# Altium schematic sheet ble_seismometer.SchDoc
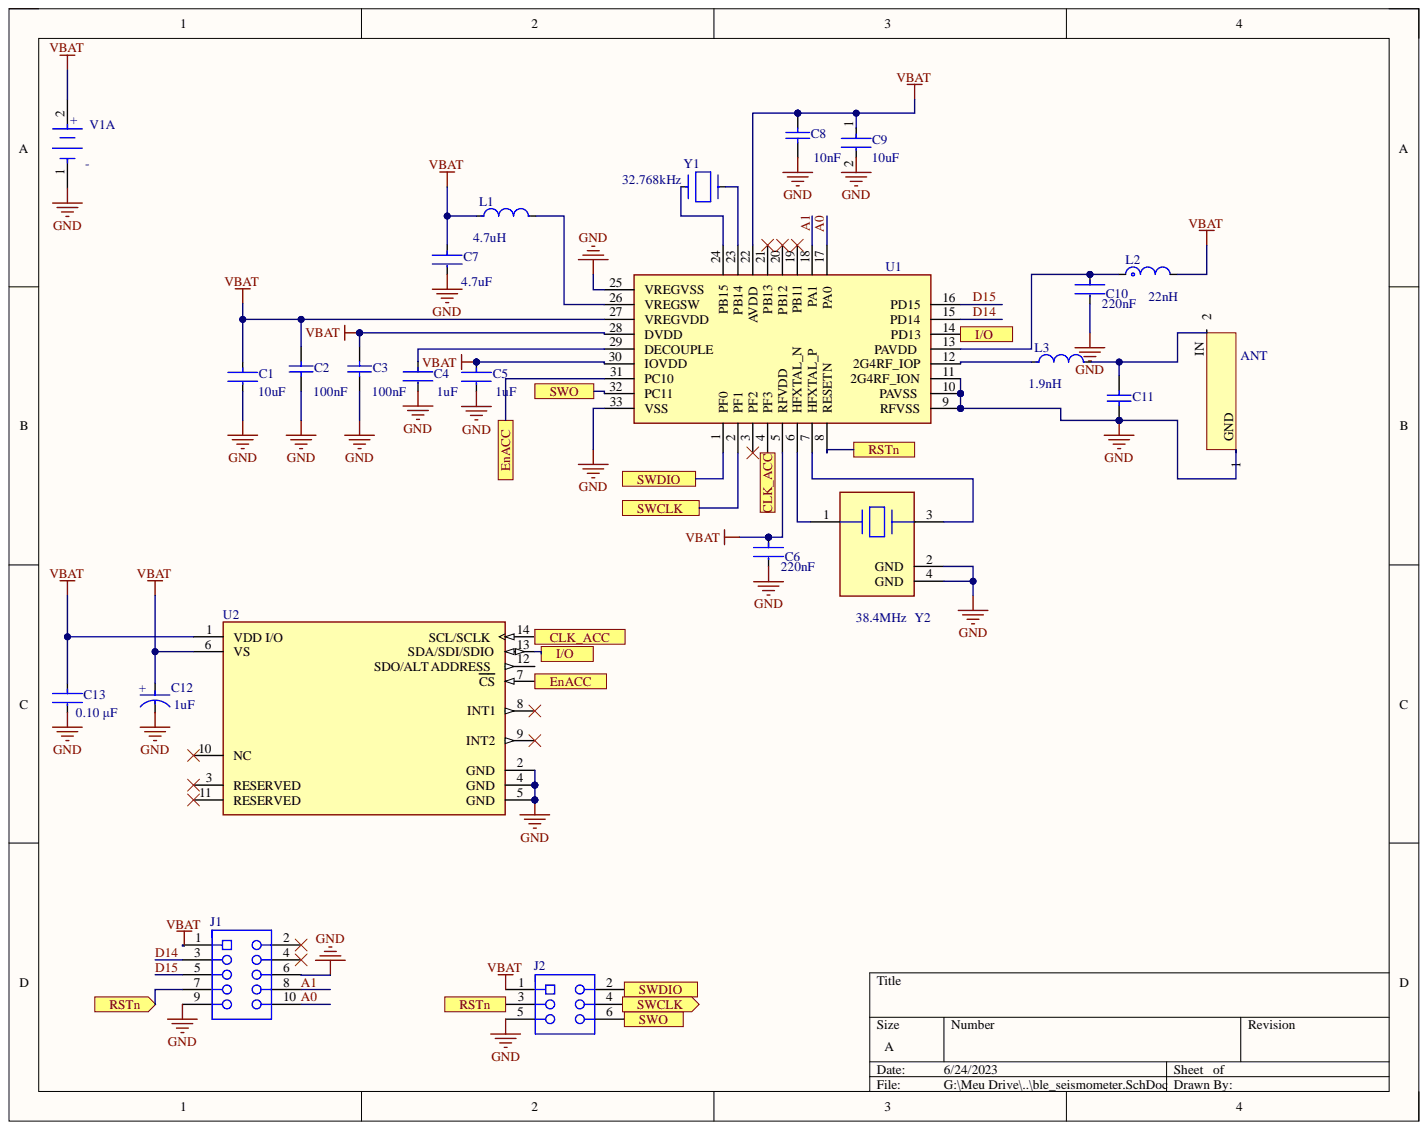
[diagram p0: ble_seismometer.SchDoc]

## Parts
ANT  Inverted F Antenna  [InvertedF-FOOTPRINT]  (value not drawn)
C1  Capacitance: 10uF  [FP-C1608-080-0_1-MFG]  (hidden comment: C1608X5R1A106K080AC)
C2  Capacitance: 100nF  [CAPC1608X90X35ML10T15]  (hidden comment: GRM188R71H104KA93D)
C3  Capacitance: 100nF  [CAPC1608X90X35ML10T15]  (hidden comment: GRM188R71H104KA93D)
C4  Capacitance: 1uF  [FP-C0402C105K8PACTU-MFG]  (hidden comment: C0402C105K8PACTU)
C5  Capacitance: 1uF  [FP-C0402C105K8PACTU-MFG]  (hidden comment: C0402C105K8PACTU)
C6  Capacitance: 220nF  [FP-GRM188-0_1-e0_2_0_5-IPC_C]  (hidden comment: GRM188R71E224KA88D)
C7  Capacitance: 4.7uF  [FP-GRM188-0_1-MFG]  (hidden comment: GRM188R60J475KE19D)
C8  Capacitance: 10nF  [CAPC1608X90X35ML10T15]  (hidden comment: GRM188R71H103KA01D)
C9  Capacitance: 10uF  [FP-GRM188-0_1-e0_2_0_55-IPC_A]  (hidden comment: GRM188R60J106ME47D)
C10  Capacitance: 220nF  [FP-GRM188-0_1-e0_2_0_5-IPC_C]  (hidden comment: GRM188R71E224KA88D)
C11  VJ0603D1R5BXPAJ  [CAPC1608X92X38ML15T08]  (value not drawn)
C12  value 1uF  [FP-F98M-MFG]  (hidden comment: F981E105MMA)
C13  value 0.10 μF  Capacitance: 0.10 μF  [FP-C0603C-CF-MFG]  (hidden comment: C0603C104K5RACTU)
J1  61301021821  [61301021821]  (value not drawn)
J2  62000621821  [62000621821]  (value not drawn)
L1  LQM18FN4R7M00D  [FP-LQM18FN_00-IPC_A]  (value not drawn)
L2  Inductance: 22nH  [FP-LQG18HH_00-IPC_C]  (hidden comment: LQG18HN22NJ00D)
L3  Inductance: 1.9nH  [FP-LQW03AW_00-MFG]  (hidden comment: LQW03AW1N9C00D)
U1  EFR32BG13P632F512GM32-D  [EFR32BG13P632F512GM32-D]  (value not drawn)
U2  ADXL345BCCZ-RL7  [FP-CC-14-1-MFG]  (value not drawn)
V1  1013  [FP-1013-MFG]  (value not drawn)  (part A)
Y1  Frequency: 32.768kHz  [FP-ABS05-MFG]  (hidden comment: ABS05-32.768KHZ-T)
Y2  Frequency: 38.4MHz  [FP-ABM10-165-MFG]  (hidden comment: ABM10-165-38.400MHZ-T3)

## Ports
CLK_ACC, EnACC, I/O, RSTn, SWCLK, SWDIO, SWO

## Nets
GND: ANT.GND C1.1 C2.1 C3.1 C4.2 C5.2 C6.2 C7.1 C8.1 C9.2 C10.2 C11.1 J1.6 J1.9 J2.5 U1.9(RFVSS) U1.10(PAVSS) U1.11(2G4RF_ION) U1.25(VREGVSS) U1.33(VSS) U2.2(GND) U2.4(GND) U2.5(GND) V1.1 Y2.2(GND) Y2.4(GND)
VBAT: C1.2 C2.2 C3.2 C5.1 C6.1 C7.2 C8.2 C9.1 C12.2 C13.1 J1.1 J2.1 L1.1 L2.2 U1.5(RFVDD) U1.22(AVDD) U1.27(VREGVDD) U1.28(DVDD) U1.30(IOVDD) U2.1(VDD_I/O) U2.6(VS) V1.2
A0: J1.10 U1.17(PA0)
A1: J1.8
CLK_ACC: U1.4(PF3) U2.14(SCL/SCLK) ; port CLK_ACC
D14: J1.3 U1.15(PD14)
EnACC: U1.31(PC10) U2.7(CS) ; port EnACC
I/O: U1.14(PD13) U2.13(SDA/SDI/SDIO) ; port I/O
RSTn: J2.3 U1.8(RESETN) ; port RSTn
SWCLK: U1.2(PF1) ; port SWCLK
SWDIO: J2.2 U1.1(PF0) ; port SWDIO
SWO: J2.6 U1.32(PC11) ; port SWO
NetANT_IN: ANT.IN C11.2 L3.2
NetC4_1: C4.1 U1.29(DECOUPLE)
NetC10_1: C10.1 L2.1 U1.13(PAVDD)
NetL1_2: L1.2 U1.26(VREGSW)
NetL3_1: L3.1 U1.12(2G4RF_IOP)
NetU1_6: U1.6(HFXTAL_N) Y2.1
NetU1_7: U1.7(HFXTAL_P) Y2.3
NetU1_23: U1.23(PB14) Y1.2
NetU1_24: U1.24(PB15) Y1.1
D15:

Not connected: C12 1; C13 2; J1 2 4 5 7; J2 4; U1 3(PF2) 16(PD15) 18(PA1) 19(PB11) 20(PB12) 21(PB13); U2 3(RESERVED) 8(INT1) 9(INT2) 10(NC) 11(RESERVED) 12(SDO/ALT_ADDRESS)

## Library data of the parts
ANT = InvertedF: Component Kind: Net Tie; Description: Inverted F Antenna; Library Name: InvertedF.SchLib
C1 = CMP-2000-04979-3: Multilayer Ceramic Capacitors 10μF ±10% 10V X5R SMD 0603; Case Code (Imperial): 0603; Case Code (Metric): 1608; Case/Package: 0603; Component Kind: Standard; Construction: Flat; Depth: 0.8mm; Dielectric: X5R; Dielectric Material: Ceramic; Height: 0.8mm; Lead Free: Lead Free; Length: 1.6mm; Library Name: Altium Content Vault; Max Operating Temperature: 85°C; Min Operating Temperature: -55°C; Mount: Surface Mount; Number of Pins: 2; Package Quantity: 1; Packaging: Tape and Reel; Radiation Hardening: No; REACH SVHC: No SVHC; Resistor Type: Ceramic; RoHS Compliant: Yes; Series: C; Termination: SMD/SMT; Thickness: 0.035inch; Tolerance: 10%; Voltage: 10V; Voltage Rating: 10V; Voltage Rating (DC): 10V; Weight: 7.1E-05oz; Width: 0.8mm
C2 C3 = CMP-2100-03643-1: Chip Capacitor, 0.1 uF, +/- 10%, 50 V, -55 to 125 degC, 0603 (1608 Metric), RoHS, Tape and Reel; Manufacturer: Murata; Dielectric: X7R; Dielectric Material: Ceramic; Insulation Resistance: 10GR; Voltage: 50V; Case Code (Imperial): 0603; Case Code (Metric): 1608; Case/Package: 0603; Component Kind: Standard; Contact Plating: Tin; Datasheet URL: http://psearch.en.murata.com/capacitor/product/GRM188R71H104KA93%23.pdf; Datasheet Version: 42675.0; Depth: 0.8mm; Height: 0.8mm; Lead Free: LeadFree; Length: 1.6mm; Library Name: Altium Content Vault; Manufacturer URL: http://www.murata.com/; Material: Ceramic; Max Operating Temperature: 125degC; Min Operating Temperature: -55degC; Mount: SurfaceMount; Number of Pins: 2; Package Description: 2-Pin Surface Mount Device, Body 1.6 x 0.8 mm; Package Quantity: 1; Package Reference: 0603; Packaging: TapeandReel; Radiation Hardening: No; REACH SVHC: NoSVHC; RoHSCompliant: true; Termination: SMD/SMT; Thickness: 0.035inch; Tolerance: 10%; Voltage Rating: 50V; Voltage Rating (DC): 50V; Width: 0.8mm
C4 C5 = CMP-2008-02081-2: CAP CER 1UF 10V X5R 0402; Dielectric Material: Ceramic; Dissipation Factor: 5%; Tolerance: 10%; Case Code (Imperial): 0402; Case Code (Metric): 1005; Case/Package: 0402; Component Kind: Standard; Construction: Flat; Contact Plating: Tin; Depth: 0.5mm; Dielectric: X5R; Height: 0.5mm; Lead Free: Lead Free; Length: 1mm; Library Name: Altium Content Vault; Max Operating Temperature: 85°C; Min Operating Temperature: -55°C; Mount: Surface Mount; Number of Pins: 2; Package Quantity: 1; Packaging: Tape and Reel; Radiation Hardening: No; REACH SVHC: No SVHC; RoHS Compliant: Yes; Series: C; Termination: SMD/SMT; Thickness: 0.022inch; Voltage: 10V; Voltage Rating: 10V; Voltage Rating (DC): 10V; Width: 0.5mm
C6 C10 = CMP-1035-02648-3: Chip Multilayer Ceramic Capacitors for General Purpose, 0603, 0.22uF, X7R, 15%, 10%, 25V; Voltage: 50V; Case Code (Imperial): 0603; Case Code (Metric): 1608; Case/Package: 0603; Component Kind: Standard; Depth: 0.8mm; Dielectric: X7R; Height: 0.8mm; Lead Free: Lead Free; Length: 1.6mm; Library Name: Altium Content Vault; Material: Ceramic; Max Operating Temperature: 125°C; Min Operating Temperature: -55°C; Mount: Surface Mount; Number of Pins: 2; Package Quantity: 1; Packaging: Tape and Reel; Radiation Hardening: No; REACH SVHC: No SVHC; Termination: SMD/SMT; Thickness: 0.035inch; Tolerance: 10%; Voltage Rating: 25V; Voltage Rating (DC): 25V; Width: 0.8mm
C7 = CMP-2006-02799-3: CAP CER 4.7UF 6.3V X5R 0603; Material: Ceramic; Tolerance: 10%; Case Code (Imperial): 0603; Case Code (Metric): 1608; Case/Package: 0603; Component Kind: Standard; Depth: 0.8mm; Dielectric: X5R; Height: 0.8mm; Lead Free: Lead Free; Length: 1.6mm; Library Name: Altium Content Vault; Max Operating Temperature: 85°C; Min Operating Temperature: -55°C; Mount: Surface Mount; Package Quantity: 1; Packaging: Tape and Reel; Radiation Hardening: No; REACH SVHC: No SVHC; RoHS Compliant: Yes; Termination: SMD/SMT; Thickness: 0.035inch; Voltage: 6.3V; Voltage Rating: 6.3V; Voltage Rating (DC): 6.3V; Width: 0.8mm
C8 = CMP-2100-03642-1: Chip Capacitor, 10 nF, +/- 10%, 50 V, -55 to 125 degC, 0603 (1608 Metric), RoHS, Tape and Reel; Manufacturer: Murata; Dielectric: X7R; Dielectric Material: Ceramic; Insulation Resistance: 10GR; Voltage: 50V; Case Code (Imperial): 0603; Case Code (Metric): 1608; Case/Package: 0603; Component Kind: Standard; Datasheet URL: http://search.murata.co.jp/Ceramy/image/img/A01X/G101/ENG/GRM188R71H103KA01-01.pdf; Datasheet Version: 42401.0; Depth: 0.8mm; Height: 0.8mm; Lead Free: LeadFree; Length: 1.6mm; Library Name: Altium Content Vault; Manufacturer URL: http://www.murata.com/; Material: Ceramic; Max Operating Temperature: 125degC; Min Operating Temperature: -55degC; Mount: SurfaceMount; Number of Pins: 2; Package Description: 2-Pin Surface Mount Device, Body 1.6 x 0.8 mm; Package Quantity: 1; Package Reference: 0603; Packaging: TapeandReel; REACH SVHC: Unknown; RoHSCompliant: True; Termination: SMD/SMT; Thickness: 0.035inch; Tolerance: 10%; Voltage Rating: 50V; Voltage Rating (DC): 50V; Width: 0.031inch
C9 = CMP-2006-02792-2: Chip Multilayer Ceramic Capacitors for General Purpose, 0603, 10uF, X5R, 15%, 20%, 6.3V; Case Code (Imperial): 0603; Case Code (Metric): 1608; Case/Package: 0603; Component Kind: Standard; Depth: 0.8mm; Dielectric: X5R; Height: 0.8mm; Lead Free: Lead Free; Length: 1.6mm; Library Name: Altium Content Vault; Material: Ceramic; Max Operating Temperature: 85°C; Min Operating Temperature: -55°C; Mount: Surface Mount; Package Quantity: 4000; Packaging: Tape and Reel; Radiation Hardening: No; REACH SVHC: No SVHC; Termination: SMD/SMT; Thickness: 0.035inch; Tolerance: 20%; Voltage: 6.3V; Voltage Rating: 6.3V; Voltage Rating (DC): 6.3V; Width: 0.031inch
C11 = CMP-2006-01499-1: Manufacturer: Vitramon; Component Kind: Standard; Library Name: Altium Content Vault; Part Number: VJ0603D1R5BXPAJ
C12 = CMP-04424-000025-1: 1µF Molded Tantalum Capacitors 25V 0603 (1608 Metric) 10Ohm; Manufacturer: AVX; Capacitance: 1uF; Dissipation Factor: 8%; Esr: 10Ω; Leakage Current: 0.5uA; Life: 1000Hours; Ripple Current: 50mA; Temperature Coefficient: 30%; Tolerance: 20%; Value: 1uF; Automotive: No; Category: Cap; Component Kind: Standard; ComponentLink2Description: Datasheet; ComponentLink2URL: http://datasheets.avx.com/F98.pdf; Device Class L1: Passive Components; Device Class L2: Capacitors; Device Class L3: Tantalum Capacitors; Digikey Description: CAP TANT 1UF 20% 25V 0603; Footprint Url: https://www.avx.com/download/software/literature/AVX-Footprint-Library.pdf; Height: 0.9mm; Lead Free: Yes; Library Name: Altium Content Vault; Manufacturer Part Number: F981E105MMA; Material: Tantalum; Mouser Description: Tantalum Capacitors - Solid SMD 1uF 25V 20% 0603,1.6x0.85x0.65mm; Octopart: https://octopart.com/f981e105mma-avx-27552246; Package: F98M; RoHS: TRUE; Temperature: -55°C to +125°C; Temperature Range High: +125°C; Temperature Range Low: -55°C; Voltage Rating: 25V
C13 = CMP-2006-03061-2: C0603 0.10 μF X7R 30ppm/°C 10.00% 50 V; Manufacturer: KEMET; Automotive Grade: Grade 1; Material: Ceramic; Tolerance: 0.1; Voltage Rating: 50 V; Automotive: Yes; Category: Cap; Component Kind: Standard; ComponentLink2Description: Datasheet; ComponentLink2URL: https://api.kemet.com/component-edge/download/datasheet/C0603C100J5GACAUTO.pdf; Device Class L1: Passive Components; Device Class L2: Capacitors; Device Class L3: Ceramic Capacitors; Digikey Description: CAP CER 0.1UF 50V X7R 0603; Height: 0.87mm; Lead Free: Yes; Library Name: Altium Content Vault; Manufacturer Part Number: C0603C104K5RACTU; Octopart: https://octopart.com/c0603c104k5ractu-kemet-139244; Package: C0603C-CF; RoHS: TRUE; Temperature: -55°C to +125°C; Temperature Characteristic: X7R; Temperature Coefficient: 30ppm/°C; Temperature Range High: +125°C; Temperature Range Low: -55°C
J1 = CMP-1502-01334-1: THT Vertical Socket Header WR-PHD, Pitch 2.54 mm, Dual Row, 10 pins; Manufacturer: Wurth Elektronik; Contact Resistance: 20mOhm; Gender: Female; Pin Number: 10; Pitch: 2.54mm; Rated Current: 3A; Withstanding Voltage: 500VAC; Working Voltage: 250VAC; Component Kind: Standard; Datasheet URL: https://katalog.we-online.de/em/datasheet/6130xx21821.pdf; Library Name: Altium Content Vault; Manufacturer URL: http://www.we-online.de; Mounting Technology: THT; Operating Temperature: -40to125degC; Orientation: Vertical; Package Reference: 61301021821; Packaging: Tray; Part Number: 61301021821; Row Number: Dual; Publisher: WurthElektronik
J2 = CMP-1502-01207-1: THT Vertical Socket Header WR-PHD, Pitch 2.00 mm, Dual Row, 6 pins; Manufacturer: Wurth Elektronik; Contact Resistance: 20mOhm; Gender: Female; Pin Number: 6; Pitch: 2.00mm; Rated Current: 2A; Withstanding Voltage: 500VAC; Working Voltage: 250VAC; Component Kind: Standard; Datasheet URL: https://katalog.we-online.de/em/datasheet/62000621821.pdf; Library Name: Altium Content Vault; Manufacturer URL: http://www.we-online.de; Mounting Technology: THT; Operating Temperature: -40to105degC; Orientation: Vertical; Package Reference: 62000621821; Packaging: Tube; Part Number: 62000621821; Row Number: Dual; Publisher: WurthElektronik
L1 = CMP-06042-016387-1: FIXED IND 4.7UH 80MA 600 MOHM; Core Material: Ferrite; DC Resistance (DCR): 600mR; Self Resonant Frequency: 50MHz; Shielding: Shielded; Test Frequency: 1MHz; Tolerance: 20%; Case Code (Imperial): 0603; Case Code (Metric): 1608; Case/Package: 0603; Component Kind: Standard; Current Rating: 80mA; DC Current: 80mA; Depth: 0.9mm; Height: 0.8mm; Height - Seated (Max): 0.035inch; Inductance: 4.7uH; Lead Free: Lead Free; Length: 1.6mm; Library Name: Altium Content Vault; Max DC Current: 80mA; Max Operating Temperature: 125°C; Military Standard: Not; Min Operating Temperature: -55°C; Mount: Surface Mount; Package Quantity: 4000; Packaging: Tape and Reel; Radiation Hardening: No; Rated Current-Max: 80mA; RoHS Compliant: Yes; Saturation Current: 80mA; Series: LQM18F; Termination: SMD/SMT; Weight: 7.1E-05oz; Width: 0.031inch
L2 = CMP-06042-016460-1: Multilayer type RF Inductor 22nH ±5% 0.5Ω 500mA 0603; Core Material: Air; Current Rating: 300mA; DC Resistance (DCR): 500mR; Q Factor: 12; Self Resonant Frequency: 2.3GHz; Shielding: Unshielded; Test Frequency: 100MHz; Case Code (Imperial): 0603; Case Code (Metric): 1608; Case/Package: 0603; Component Kind: Standard; DC Current: 300mA; Depth: 0.95mm; Height: 0.8mm; Height - Seated (Max): 0.037inch; Inductance Tolerance: 5%; Lead Free: Lead Free; Length: 1.6mm; Library Name: Altium Content Vault; Max DC Current: 300mA; Max Operating Temperature: 85°C; Military Standard: Not; Min Operating Temperature: -40°C; Mount: Surface Mount; Package Quantity: 4000; Packaging: Tape and Reel; Radiation Hardening: No; REACH SVHC: No SVHC; RoHS Compliant: Yes; Series: LQG18H; Termination: SMD/SMT; Tolerance: 5%; Width: 0.031inch
L3 = CMP-06042-002256-1: Wire Wound RF Inductor 1.9nH ±0.2nH 620mA 0.08Ω 0201 (0603); DC Resistance (DCR): 80mR; Self Resonant Frequency: 19GHz; Shielding: Unshielded; Test Frequency: 100MHz; Case/Package: Nonstandard; Component Kind: Standard; Current Rating: 620mA; Library Name: Altium Content Vault; Max Operating Temperature: 125°C; Min Operating Temperature: -55°C; Mount: Surface Mount; Packaging: Tape and Reel; Resistor Type: Wirewound
U1 = EFR32BG13P632F512GM32-D: RF System on a Chip - SoC Blue Gecko BLE SoC QFN48 2.4 G 10 dB BLE/proprietary 512 kB 64 kB (RAM) 16GPIO; Manufacturer_Name: Silicon Labs; Geometry.Height: 0.9mm; Component Kind: Standard; Datasheet Link: https://www.silabs.com/documents/public/data-sheets/efr32bg13-datasheet.pdf; Library Name: EFR32BG13P632F512GM32-D.SchLib; Manufacturer_Part_Number: EFR32BG13P632F512GM32-D; Mouser Part Number: 634-BGP632F512GM32D; Mouser Price/Stock: https://www.mouser.co.uk/ProductDetail/Silicon-Labs/EFR32BG13P632F512GM32-D?qs=EBDBlbfErPzhsDxvdSLfmw%3D%3D
U2 = CMP-0885-00033-2: ACCEL 2-16G I2C/SPI 14LGA; -3db Bandwidth: 1.6kHz; Axis: X, Y, Z; Bandwidth: 0.05Hz; Max Supply Voltage: 3.6V; Min Supply Voltage: 2V; Nominal Supply Current: 140uA; Operating Supply Current: 145uA; Output Type: Digital; Sensitivity: 256LSB/g; Case/Package: LGA; Component Kind: Standard; Depth: 3mm; Lead Free: Contains Lead; Length: 5mm; Library Name: Altium Content Vault; Max Operating Temperature: 85°C; Min Operating Temperature: -40°C; Number of Pins: 14; Package Quantity: 1; Packaging: Tape and Reel; Radiation Hardening: No
V1 = CMP-19636-000024-1: BATTERY HOLDER AA 2 CELL PC PIN; Number of Batteries: 2; Color: Black; Component Kind: Standard; Contact Plating: Nickel; Lead Free: Lead Free; Library Name: Altium Content Vault; Material: Nylon; Max Operating Temperature: 145°C; Min Operating Temperature: -50°C; Mount: Through Hole; Number of Cells: 2; Package Quantity: 1; Packaging: Tray; REACH SVHC: No SVHC; Series: -; Termination: PC Pin
Y1 = CMP-27762-008890-2: Crystal 32.768kHz ±20ppm (Tol) 12.5pF FUND 90kΩ 2-Pin SMD T/R; ESR (Equivalent Series Resistance): 90kR; Case/Package: CSMD; Component Kind: Standard; Depth: 1mm; Frequency Tolerance: 20ppm; Height: 0.5mm; Lead Free: Lead Free; Length: 1.6mm; Library Name: Altium Content Vault; Load Capacitance: 12.5pF; Max Operating Temperature: 85°C; Min Operating Temperature: -40°C; Mount: Surface Mount; Number of Pins: 2; Operating Frequency: 32.768 kHz; Package Quantity: 3000; Packaging: Tape and Reel; Radiation Hardening: No; REACH SVHC: No SVHC; RoHS Compliant: Yes; Series: ABS05; Series Resistance: 90kR; Termination: SMD/SMT; Tolerance: 20ppm; Width: 1mm
Y2 = CMP-27762-010013-1: CRYSTAL 38.4000MHZ 10PF SMD; ESR (Equivalent Series Resistance): 40R; Frequency Stability: 20ppm; Case/Package: SMD/SMT; Component Kind: Standard; Height: 0.02inch; Length: 0.098inch; Library Name: Altium Content Vault; Load Capacitance: 10pF; Max Operating Temperature: 85°C; Min Operating Temperature: -30°C; Mount: Surface Mount; Packaging: Tape and Reel; RoHS Compliant: Yes; Width: 0.079inch
